# Altium schematic sheet 07_P2_Conector.SchDoc
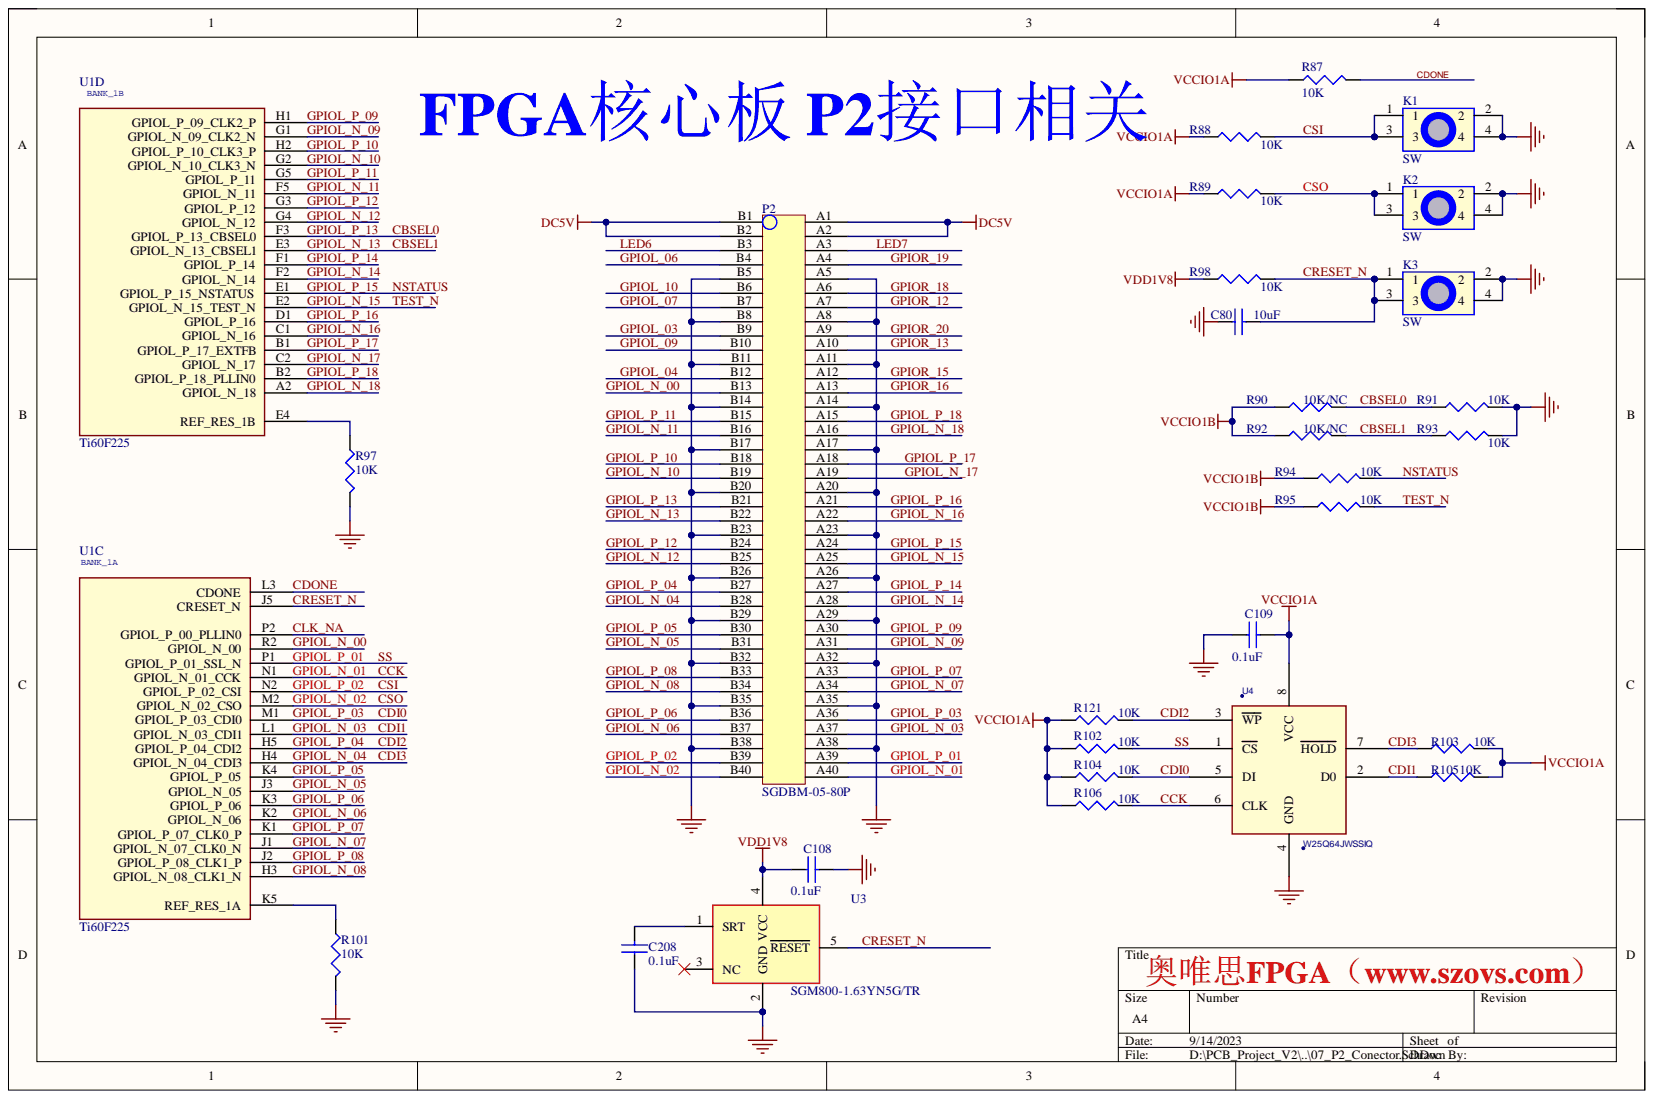
[diagram p0: 07_P2_Conector.SchDoc]

## Parts
C80  10uF  [R0603]
C108  0.1uF  [R0402]
C109  0.1uF  [R0402]
C208  0.1uF  [R0402]
K1  SW  [SW-SMD_4P-L3.0-W2.8-P1.65-TL_GT-TS171A-H014-L1]
K2  SW  [SW-SMD_4P-L3.0-W2.8-P1.65-TL_GT-TS171A-H014-L1]
K3  SW  [SW-SMD_4P-L3.0-W2.8-P1.65-TL_GT-TS171A-H014-L1]
P2  SGDBM-05-80P  [P2P_0.5mm_80P]
R87  10K  [R0402]
R88  10K  [R0402]
R89  10K  [R0402]
R90  10K/NC  [R0402]
R91  10K  [R0402]
R92  10K/NC  [R0402]
R93  10K  [R0402]
R94  10K  [R0402]
R95  10K  [R0402]
R97  10K  [R0402]
R98  10K  [R0402]
R101  10K  [R0402]
R102  10K  [R0402]
R103  10K  [R0402]
R104  10K  [R0402]
R105  10K  [R0402]
R106  10K  [R0402]
R121  10K  [R0402]
U1  Ti60F225  [ti60F225]  (parts C,D)
U3  SGM800-1.63YN5G/TR  [SOT-23-5_L2.9-W1.6-P0.95-LS2.8-BR]
U4  W25Q64JWSSIQ  [SOIC8]

## Nets
DC5V: P2.A1 P2.A2 P2.B1 P2.B2
GND: C80.1 C108.2 C109.1 C208.1 K1.2 K1.4 K2.2 K2.4 K3.2 K3.4 P2.A5 P2.A8 P2.A11 P2.A14 P2.A17 P2.A20 P2.A23 P2.A26 P2.A29 P2.A32 P2.A35 P2.A38 P2.B5 P2.B8 P2.B11 P2.B14 P2.B17 P2.B20 P2.B23 P2.B26 P2.B29 P2.B32 P2.B35 P2.B38 R91.2 R93.2 R97.2 R101.2 U3.2(GND) U4.4(GND)
VCCIO1A: C109.2 R87.1 R88.1 R89.1 R102.1 R103.2 R104.1 R105.2 R106.1 R121.1 U4.8(VCC)
VCCIO1B: R90.1 R92.1 R94.1 R95.1
VDD1V8: C108.1 R98.1 U3.4(VCC)
CBSEL0: P2.B21 R90.2 R91.1 U1.F3(GPIOL_P_13_CBSEL0)
CBSEL1: P2.B22 R92.2 R93.1 U1.E3(GPIOL_N_13_CBSEL1)
CCK: P2.A40 R106.2 U1.N1(GPIOL_N_01_CCK) U4.6(CLK)
CDI0: P2.A36 R104.2 U1.M1(GPIOL_P_03_CDI0) U4.5(DI)
CDI1: P2.A37 R105.1 U1.L1(GPIOL_N_03_CDI1) U4.2(D0)
CDI2: P2.B27 R121.2 U1.H5(GPIOL_P_04_CDI2) U4.3(WP)
CDI3: P2.B28 R103.1 U1.H4(GPIOL_N_04_CDI3) U4.7(HOLD)
CDONE: R87.2 U1.L3(CDONE)
CLK_NA: U1.P2(GPIOL_P_00_PLLIN0)
CRESET_N: C80.2 K3.1 K3.3 R98.2 U1.J5(CRESET_N) U3.5(RESET)
CSI: K1.1 K1.3 P2.B39 R88.2 U1.N2(GPIOL_P_02_CSI)
CSO: K2.1 K2.3 P2.B40 R89.2 U1.M2(GPIOL_N_02_CSO)
GPIOL_03: P2.B9
GPIOL_04: P2.B12
GPIOL_06: P2.B4
GPIOL_07: P2.B7
GPIOL_09: P2.B10
GPIOL_10: P2.B6
GPIOL_N_00: P2.B13 U1.R2(GPIOL_N_00)
GPIOL_N_05: P2.B31 U1.J3(GPIOL_N_05)
GPIOL_N_06: P2.B37 U1.K2(GPIOL_N_06)
GPIOL_N_07: P2.A34 U1.J1(GPIOL_N_07_CLK0_N)
GPIOL_N_08: P2.B34 U1.H3(GPIOL_N_08_CLK1_N)
GPIOL_N_09: P2.A31 U1.G1(GPIOL_N_09_CLK2_N)
GPIOL_N_10: P2.B19 U1.G2(GPIOL_N_10_CLK3_N)
GPIOL_N_11: P2.B16 U1.F5(GPIOL_N_11)
GPIOL_N_12: P2.B25 U1.G4(GPIOL_N_12)
GPIOL_N_14: P2.A28 U1.F2(GPIOL_N_14)
GPIOL_N_15: P2.A25 R95.2 U1.E2(GPIOL_N_15_TEST_N)
GPIOL_N_16: P2.A22 U1.C1(GPIOL_N_16)
GPIOL_N_17: P2.A19 U1.C2(GPIOL_N_17)
GPIOL_N_18: P2.A16 U1.A2(GPIOL_N_18)
GPIOL_P_01: P2.A39 R102.2 U1.P1(GPIOL_P_01_SSL_N) U4.1(CS)
GPIOL_P_05: P2.B30 U1.K4(GPIOL_P_05)
GPIOL_P_06: P2.B36 U1.K3(GPIOL_P_06)
GPIOL_P_07: P2.A33 U1.K1(GPIOL_P_07_CLK0_P)
GPIOL_P_08: P2.B33 U1.J2(GPIOL_P_08_CLK1_P)
GPIOL_P_09: P2.A30 U1.H1(GPIOL_P_09_CLK2_P)
GPIOL_P_10: P2.B18 U1.H2(GPIOL_P_10_CLK3_P)
GPIOL_P_11: P2.B15 U1.G5(GPIOL_P_11)
GPIOL_P_12: P2.B24 U1.G3(GPIOL_P_12)
GPIOL_P_14: P2.A27 U1.F1(GPIOL_P_14)
GPIOL_P_15: P2.A24 R94.2 U1.E1(GPIOL_P_15_NSTATUS)
GPIOL_P_16: P2.A21 U1.D1(GPIOL_P_16)
GPIOL_P_17: P2.A18 U1.B1(GPIOL_P_17_EXTFB)
GPIOL_P_18: P2.A15 U1.B2(GPIOL_P_18_PLLIN0)
GPIOR_12: P2.A7
GPIOR_13: P2.A10
GPIOR_15: P2.A12
GPIOR_16: P2.A13
GPIOR_18: P2.A6
GPIOR_19: P2.A4
GPIOR_20: P2.A9
LED6: P2.B3
LED7: P2.A3
NetC208_2: C208.2 U3.1(SRT)
NetR97_1: R97.1 U1.E4(REF_RES_1B)
NetR101_1: R101.1 U1.K5(REF_RES_1A)

Not connected: U3 3(NC)

## Library data of the parts
C80 C108 C109 C208 = C0402: Component Kind: Standard; Library Name: 162_Library.SchLib
R87-R95 R97 R98 R101-R106 R121 = R: Component Kind: Standard; Library Name: 162_Library.SchLib
U1 = Ti60F225: SPLIT_INST: TRUE; SWAP_INFO: (S1+S2+S3+S4+S5+S6+S7+S8+S9+S10+S11+S12+S13); Component Kind: Standard; Library Name: 162_Library.SchLib
U3 = SGM800: Component Kind: Standard; Library Name: my_sch_Lib.SchLib
U4 = 150-00018, W25Q32JVSSIQ: SPIFLASH, 32M-BIT, 4KB; MFG1: Winbond; Component Kind: Standard; MFG1 PN: W25Q32JVSSIQ; Part Number: 150-00018; Part Type: IC
K1-K3 = SW: Component Kind: Standard; Library Name: my_sch_Lib.SchLib
P2 = SGDBM-05-80P: Component Kind: Standard; Library Name: my_sch_Lib.SchLib
